# Altium schematic sheet Power_Supply.SchDoc
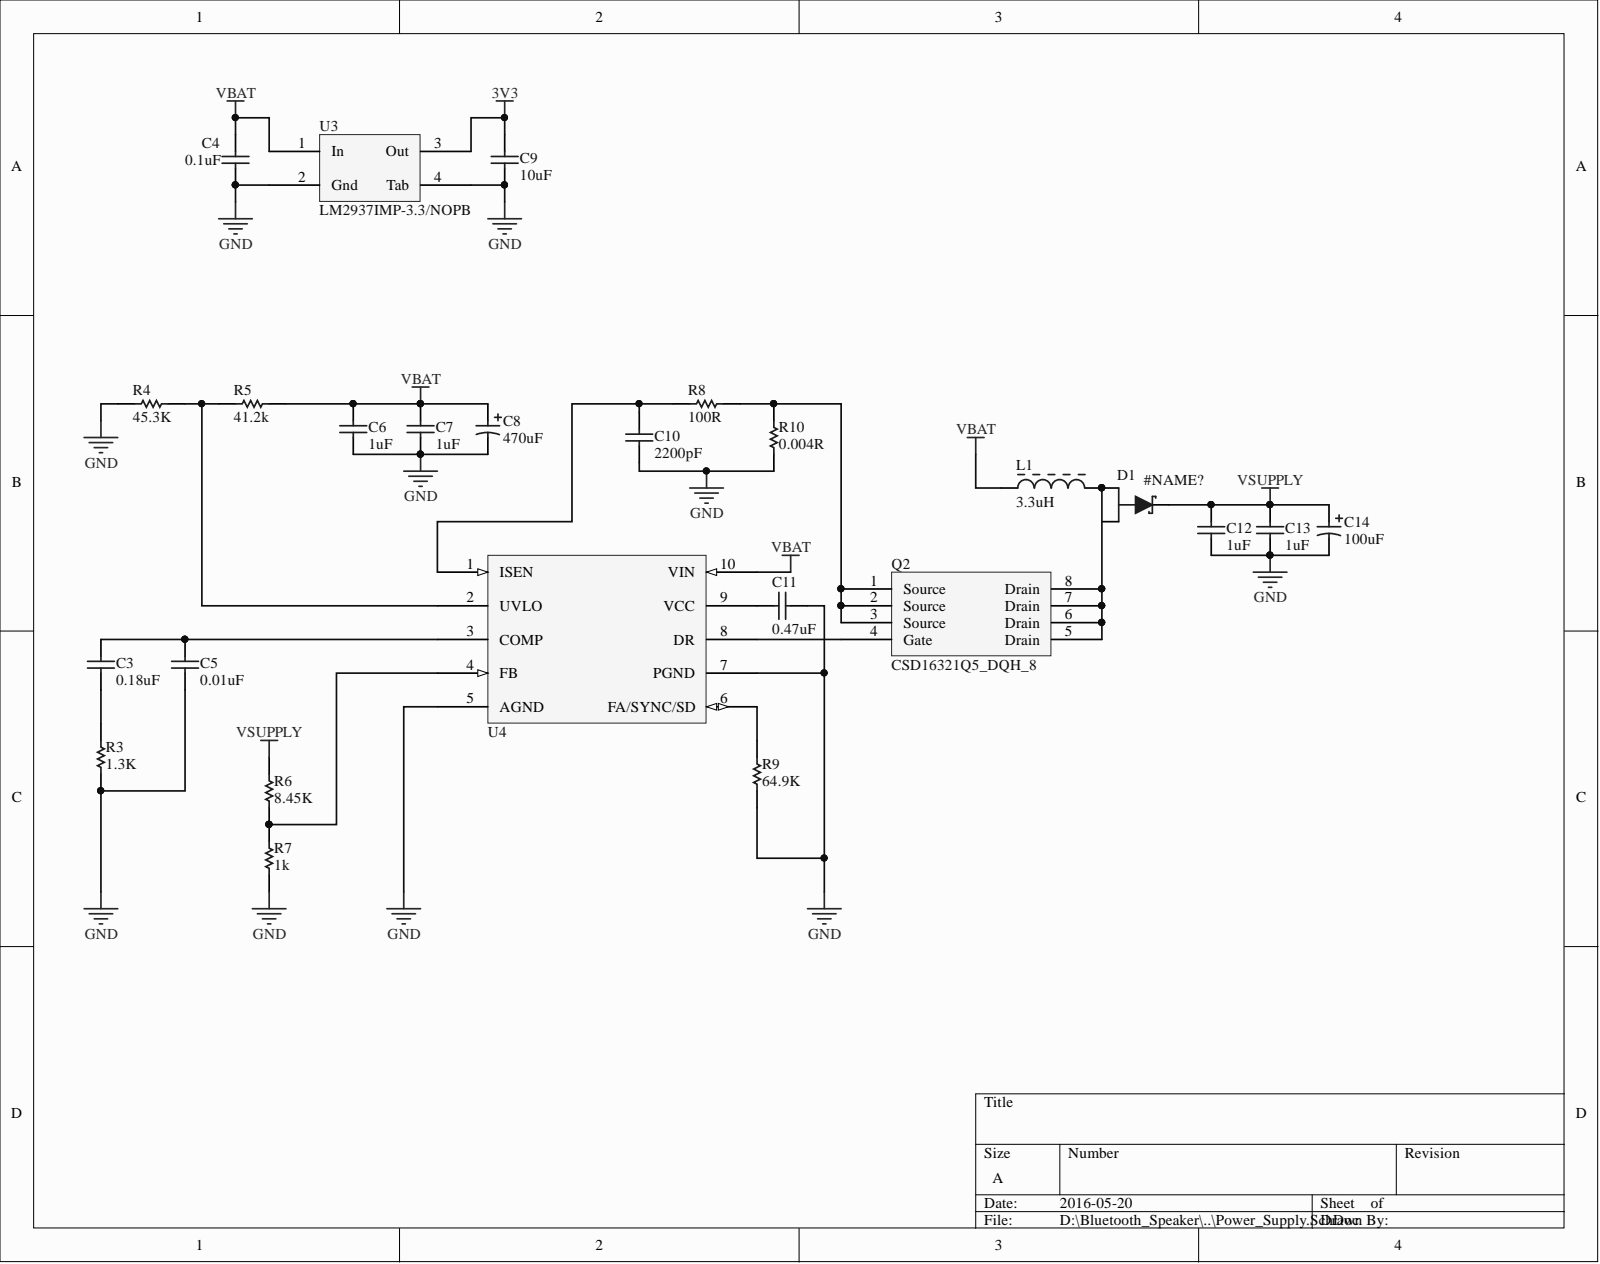
[diagram p0: Power_Supply.SchDoc]

## Parts
C3  0.18uF  [CAPC1608X09N]
C4  0.1uF  [CAPC1608X09N]
C5  0.01uF  [CAPC1608X09N]
C6  1uF  [CAPC1608X09N]
C7  1uF  [CAPC1608X09N]
C8  470uF  [NICHICON 8x10 CAP]
C9  10uF  [CAPC3216X18N]
C10  2200pF  [CAPC1608X09N]
C11  0.47uF  [CAPC1608X09N]
C12  1uF  [CAPC1608X09N]
C13  1uF  [CAPC1608X09N]
C14  100uF  [PCAP_8x10-ELEC00]
D1  #NAME?  [TO-252-3]
L1  3.3uH  [IND_BOURNS_SRP00 - duplicate]
Q2  CSD16321Q5_DQH_8  [DQH8]
R3  1.3K  [0603]
R4  45.3K  [0603]
R5  41.2k  [0603]
R6  8.45K  [0603]
R7  1k  [0603]
R8  100R  [0603]
R9  64.9K  [0603]
R10  0.004R  [2512]
U3  LM2937IMP-3.3/NOPB  [DSO-G3/C6.4]
U4  LM3481  [VSSOP10]  (value not drawn)

## Nets
3V3: C9.2 U3.3(Out)
GND: C4.1 C5.1 C6.1 C7.1 C8.2 C9.1 C10.1 C11.2 C12.1 C13.1 C14.2 R3.1 R4.1 R7.1 R9.1 R10.1 U3.2(Gnd) U3.4(Tab) U4.5(AGND) U4.7(PGND)
VBAT: C4.2 C6.2 C7.2 C8.1 L1.1 R5.2 U3.1(In) U4.10(VIN)
VSUPPLY: C12.2 C13.2 C14.1 D1.4 R6.1
NetC3_1: C3.1 R3.2
NetC3_2: C3.2 C5.2 U4.3(COMP)
NetC10_2: C10.2 R8.1 U4.1(ISEN)
NetC11_1: C11.1 U4.9(VCC)
NetD1_1: D1.1 L1.2 Q2.5(Drain) Q2.6(Drain) Q2.7(Drain) Q2.8(Drain)
NetQ2_1: Q2.1(Source) Q2.2(Source) Q2.3(Source) R8.2 R10.2
NetQ2_4: Q2.4(Gate) U4.8(DR)
NetR4_2: R4.2 R5.1 U4.2(UVLO)
NetR6_2: R6.2 R7.2 U4.4(FB)
NetR9_2: R9.2 U4.6(FA/SYNC/SD)

Not connected: D1 3

## Library data of the parts
C3 = CAP CER 0.18uF 0603: Manufacturer: Murata; Rating: X7R; Voltage: 25V; Component Kind: Standard; Digikey Part Number: 490-6431-1-ND; Package: 0603; Value: 0.18uF; Library Name: Speaker Library.SchLib
C4 = CAP CER 0.1uF 0603: Rating: X7R; Tolerance: 10%; Voltage: 100V; Component Kind: Standard; Digikey Part Number: 490-3285-1-ND; Package: 0603; Value: 0.1uF; Library Name: Speaker Library.SchLib
C5 = CAP CER 0.01uF 0603: Manufacturer: Murata; Rating: C0G; Component Kind: Standard; Digikey Part Number: 490-9666-1-ND; Value: 0.01uF; Library Name: Speaker Library.SchLib
C6 C7 C12 C13 = CAP CER 1uF 0603: Voltage: 35V; Component Kind: Standard; Digikey Part Number: 490-10487-1-ND; Value: 1uF; Library Name: Speaker Library.SchLib
C8 = CAP ALUM 470uF SMD: Component Kind: Standard; Digikey Part Number: 493-2181-1-ND; Value: 470uF; Library Name: Speaker Library.SchLib
C9 = CAP CER 10uF 1206: 3V3 filter cap; Rating: X7R; Voltage: 25V; Component Kind: Standard; Digikey Part Number: 490-6518-1-ND; Value: 10uF; Library Name: Speaker Library.SchLib
C10 = CAP CER 2200pF 0603: Manufacturer: Murata; Rating: C0G; Tolerance: 1%; Voltage: 50V; Component Kind: Standard; Digikey Part Number: 490-7768-1-ND; Value: 2200pF; Library Name: Speaker Library.SchLib
C11 = CAP CER 0.47uF 0603: Rating: X7R; Voltage: 25V; Component Kind: Standard; Digikey Part Number: 490-3291-1-ND; Value: 0.47uF; Library Name: Speaker Library.SchLib
C14 = CAP ALUM 100uF SMD: Manufacturer: Nichicon; Voltage: 50V; Component Kind: Standard; Digikey Part Number: 493-2226-1-ND; Value: 100uF; Library Name: Speaker Library.SchLib
D1 = MBRD835LT4G: SHOTTKEY 35V 8A; Configuration: Single; Type: SCHOT; Component Kind: Standard; Current: 8A; Digikey Part Number: MBRD835LT4GOSCT-ND; Voltage: 35V; Library Name: Speaker Library.SchLib
L1 = IND 3.3µH 7A SMD: Component Kind: Standard; Digikey Part Number: SRP7030-3R3FMCT-ND; Value: 3.3uH; Library Name: Speaker Library.SchLib
Q2 = CSD16321Q5_DQH_8: Manufacturer: Texas Instruments; FAMILY_NAME: N-Channel MOSFET Transistors; INDUSTRY_STD_PKG_TYPE: SON; PACKAGE_DESIGNATOR: DQH; PIN_COUNT: 8; Type: DEV; Component Kind: Standard; Datasheet URL: http://www.ti.com/lit/gpn/CSD16321Q5; Digikey Part Number: 296-25643-1-ND; GENERIC_PART_NUMBER: CSD16321Q5; RefDes: RefDes; Value: Value; Library Name: Speaker Library.SchLib
R3 = RES 1.3K 0603: Manufacturer: Vishay Dale; Power: 0.1W; Tolerance: 1%; Component Kind: Standard; Digikey Part Number: 541-1.30KHCT-ND; Type: RES; Value: 1.3K; Library Name: Speaker Library.SchLib
R4 = RES 45.3K 0603: Manufacturer: Vishay Dale; Power: 0.1W; Tolerance: 1%; Component Kind: Standard; Digikey Part Number: 541-45.3KHCT-ND; Type: RES; Value: 45.3K; Library Name: Speaker Library.SchLib
R5 = RES 41.2K 0603: Manufacturer: Vishay Dale; Power: 0.1W; Tolerance: 1%; Component Kind: Standard; Digikey Part Number: 311-41.2KHRCT-ND; Type: RES; Value: 41.2k; Library Name: Speaker Library.SchLib
R6 = RES 8.45K 0603: Manufacturer: Vishay Dale; Power: 0.1W; Tolerance: 1%; Component Kind: Standard; Digikey Part Number: 541-8.45KHCT-ND; Type: RES; Value: 8.45K; Library Name: Speaker Library.SchLib
R7 = RES 1K 0603: Manufacturer: Panasonic; Manufacturer Part Number: ERJ-PA3F1001V; Power: 0.25W; Tolerance: 1%; Component Kind: Standard; Digikey Part Number: P1.0KBYCT-ND; Temperature: 155dC; Type: RES; Value: 1k; Library Name: Speaker Library.SchLib
R8 = RES 100R 0603: Power: 0.25W; Tolerance: 1%; Component Kind: Standard; Digikey Part Number: 541-100SACT-ND; Type: RES; Value: 100R; Library Name: Speaker Library.SchLib
R9 = RES 64.9K 0603: Manufacturer: Vishay Dale; Power: 0.1W; Tolerance: 1%; Component Kind: Standard; Digikey Part Number: 541-64.9KHCT-ND; Type: RES; Value: 64.9K; Library Name: Speaker Library.SchLib
R10 = RES 0.004R 2512: Manufacturer: Panasonic; Power: 1W; Tolerance: 1%; Component Kind: Standard; Digikey Part Number: P4.0MCT-ND; Type: RES; Value: 0.004R; Library Name: Speaker Library.SchLib
U4 = LM3481: IC REG CTRLR MULT TOP 10MSOP; Component Kind: Standard; Digikey Part Number: LM3481MM/NOPBCT-ND; Library Name: Speaker Library.SchLib
U3 = LM2937IMP-3.3/NOPB: Component Kind: Standard; Digikey Part Number: LM2937IMP-3.3/NOPBCT-ND; Library Name: Speaker Library.SchLib
